# Altium schematic sheet DAC_TEST.SchDoc
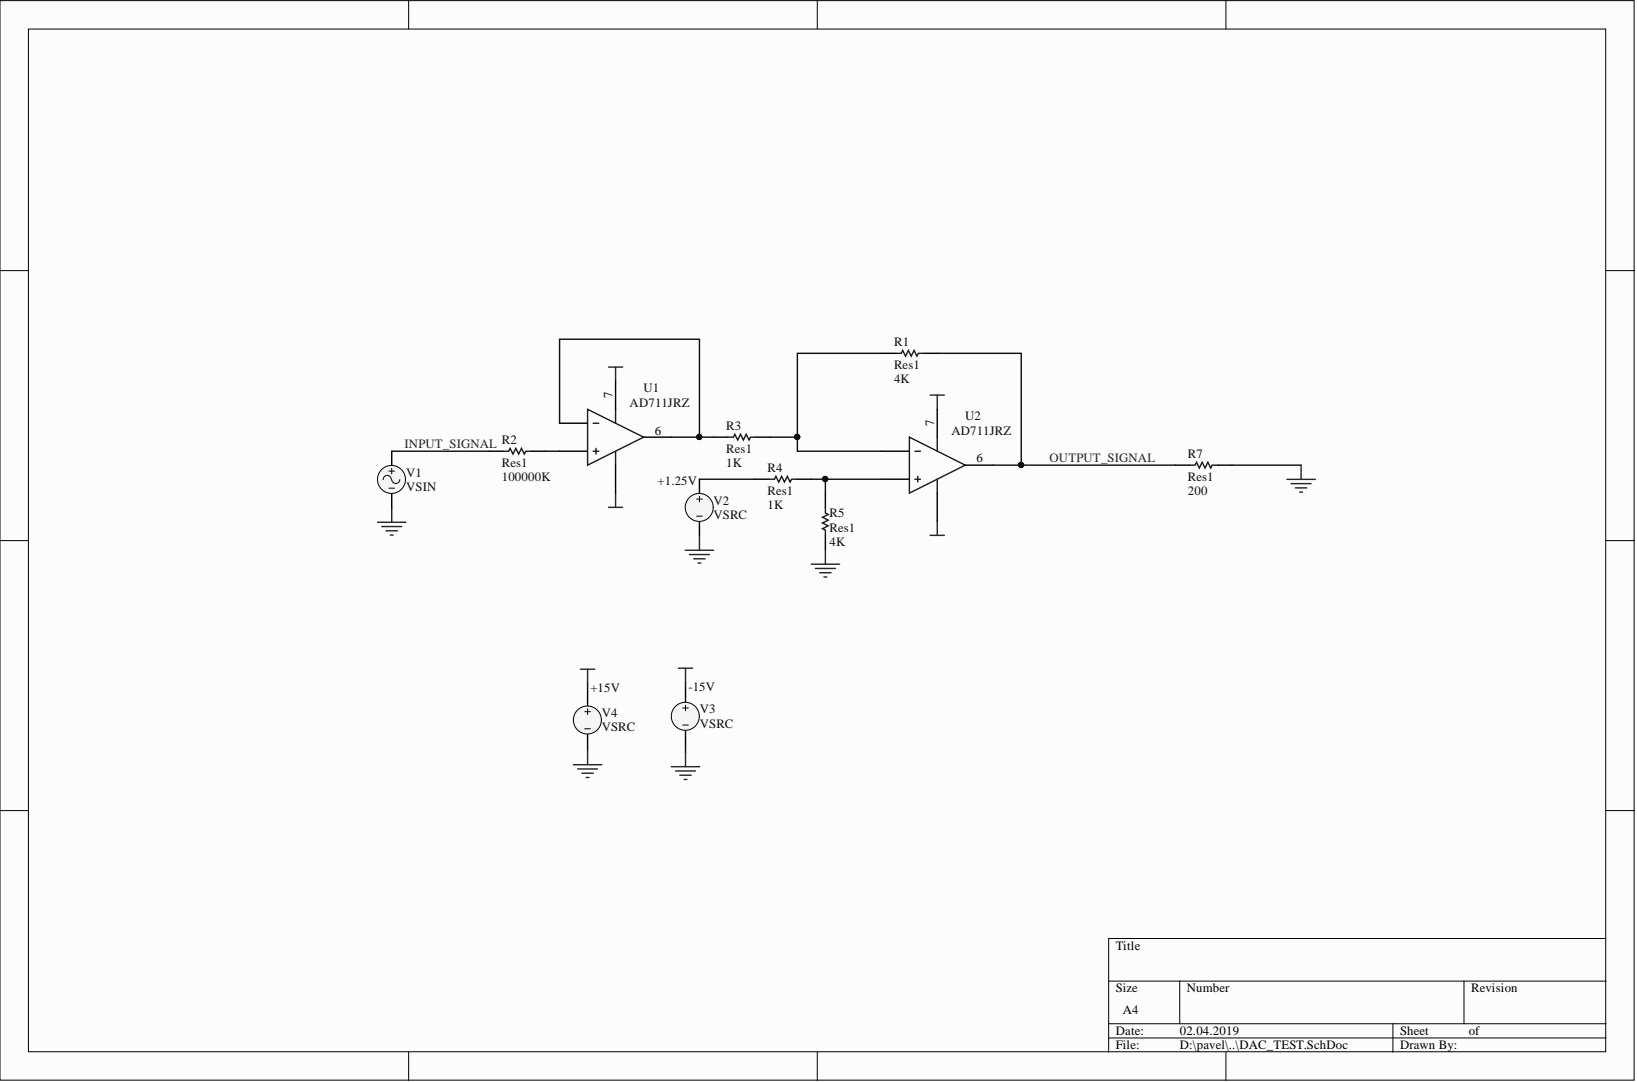
[diagram p0: DAC_TEST.SchDoc]

## Parts
R1  Res1  value 4K  [AXIAL-0.3]
R2  Res1  value 100000K  [AXIAL-0.3]
R3  Res1  value 1K  [AXIAL-0.3]
R4  Res1  value 1K  [AXIAL-0.3]
R5  Res1  value 4K  [AXIAL-0.3]
R7  Res1  value 200  [AXIAL-0.3]
U1  AD711JRZ
U2  AD711JRZ
V1  VSIN
V2  VSRC  value +1.25V
V3  VSRC  value -15V
V4  VSRC  value +15V

## Nets
GND: R5.1 R7.2 V1.2 V2.2 V3.2 V4.2
VCC: U1.7 U2.7 V4.1
VEE: U1.4 U2.4 V3.1
INPUT_SIGNAL: R2.1 V1.1
OUTPUT_SIGNAL: R1.2 R7.1 U2.6
NetR1_1: R1.1 R3.2 U2.2
NetR2_2: R2.2 U1.3
NetR3_1: R3.1 U1.2 U1.6
NetR4_1: R4.1 V2.1
NetR4_2: R4.2 R5.2 U2.3

## Library data of the parts
R1 R3-R5 R7 = Res1: Resistor; Component Kind: Standard; LatestRevisionDate: 17-Jul-2002; LatestRevisionNote: Re-released for DXP Platform.; Library Name: Miscellaneous Devices.IntLib; PackageDescription: Axial Device, Thru-Hole; 2 Leads; 0.3 in Pin Spacing; PackageReference: AXIAL-0.3; Published: 8-Jun-2000; Signal Integrity: Res; Simulation: RESISTOR; Publisher: Altium Limited
R2 = Res1: Resistor; Value: 100000K; Component Kind: Standard; LatestRevisionDate: 17-Jul-2002; LatestRevisionNote: Re-released for DXP Platform.; Library Name: Miscellaneous Devices.IntLib; PackageDescription: Axial Device, Thru-Hole; 2 Leads; 0.3 in Pin Spacing; PackageReference: AXIAL-0.3; Published: 8-Jun-2000; Signal Integrity: Res; Simulation: RESISTOR; Publisher: Altium Limited
U1 U2 = AD711JRZ: Operational Amplifier; Component Kind: Standard; Library Name: CAP_TEST.SchLib; Simulation: AD711
V1 = VSIN: Sinusoidal Voltage Source; Note: PCB Footprint - Not required; Component Kind: Standard; LatestRevisionDate: 15-Nov-2004; LatestRevisionNote: Corrected Netlist.; Library Name: Simulation Sources.IntLib; PackageReference: Not Applicable; Published: 8-Jun-2000; Simulation: VSIN; Publisher: Altium Limited
V2-V4 = VSRC: Voltage Source; Note: PCB Footprint - Not required; Component Kind: Standard; LatestRevisionDate: 17-Jul-2002; LatestRevisionNote: Re-released for DXP Platform.; Library Name: Simulation Sources.IntLib; PackageReference: Not Applicable; Published: 8-Jun-2000; Simulation: VSRC; Publisher: Altium Limited
